# Altium schematic sheet mainb.SchDoc(mainb)
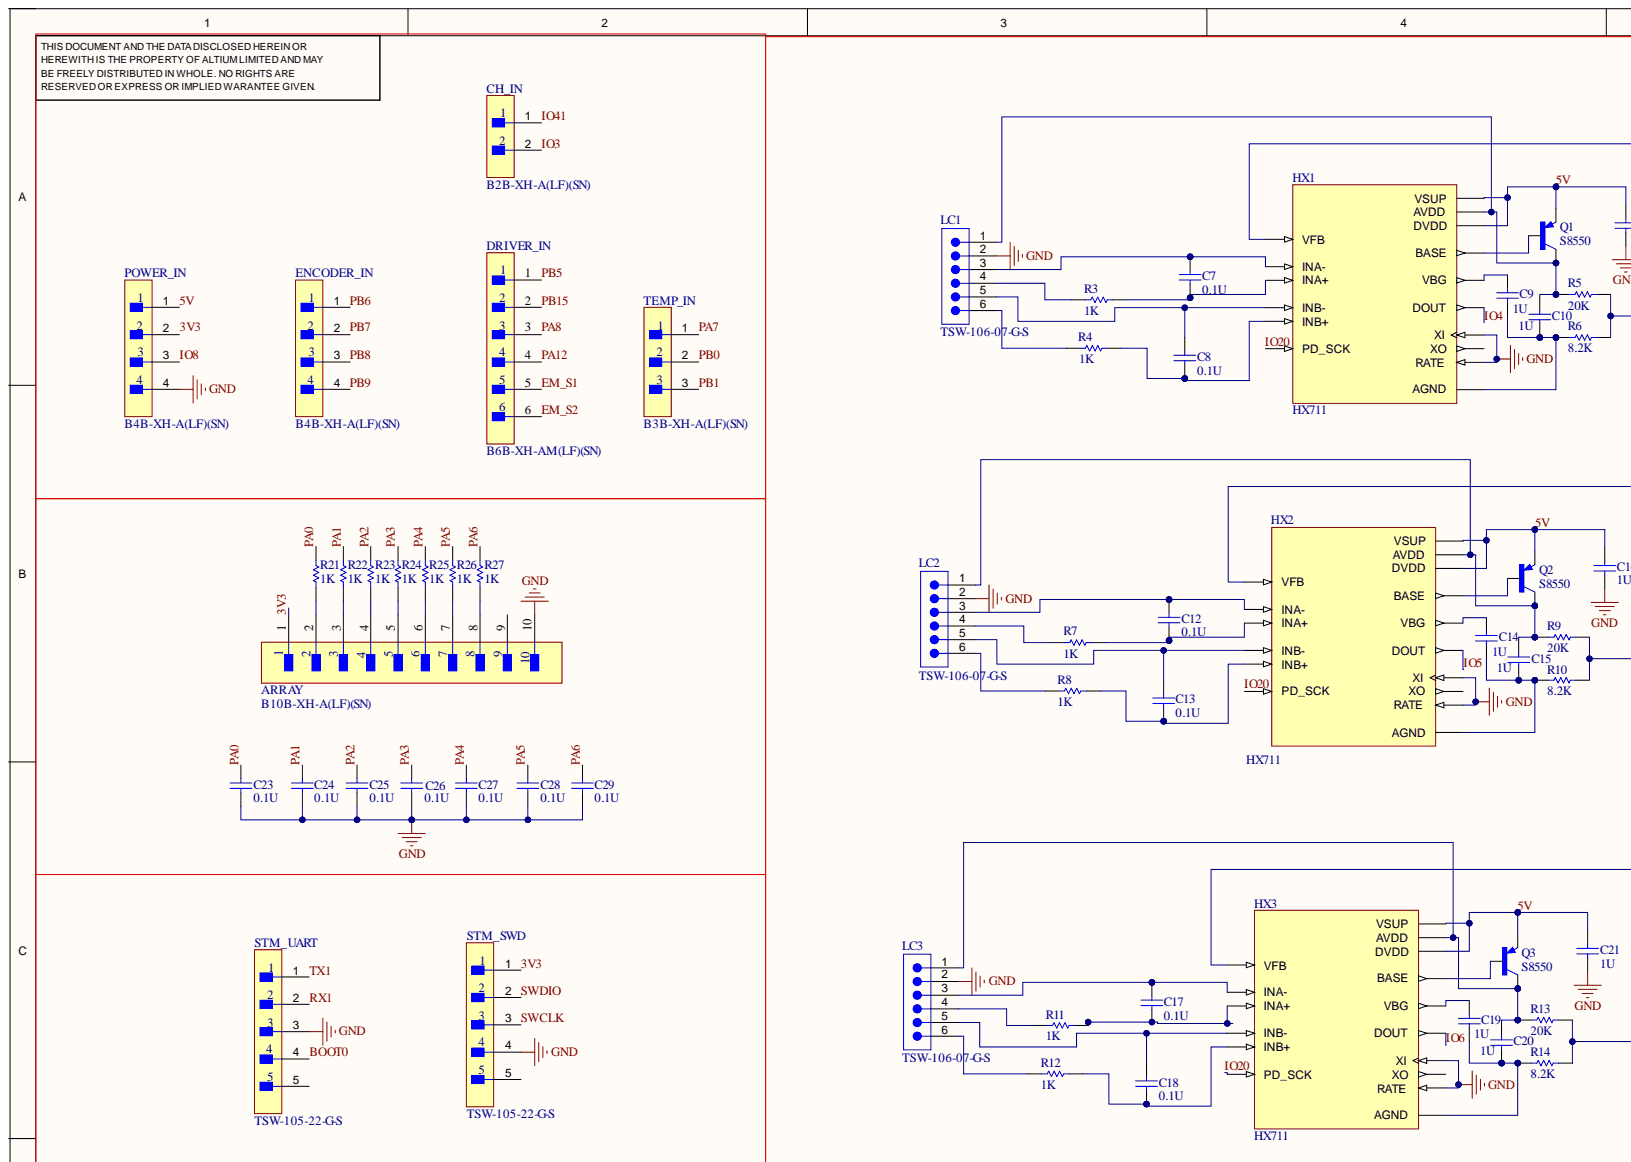
[diagram p0: mainb.SchDoc, top left]
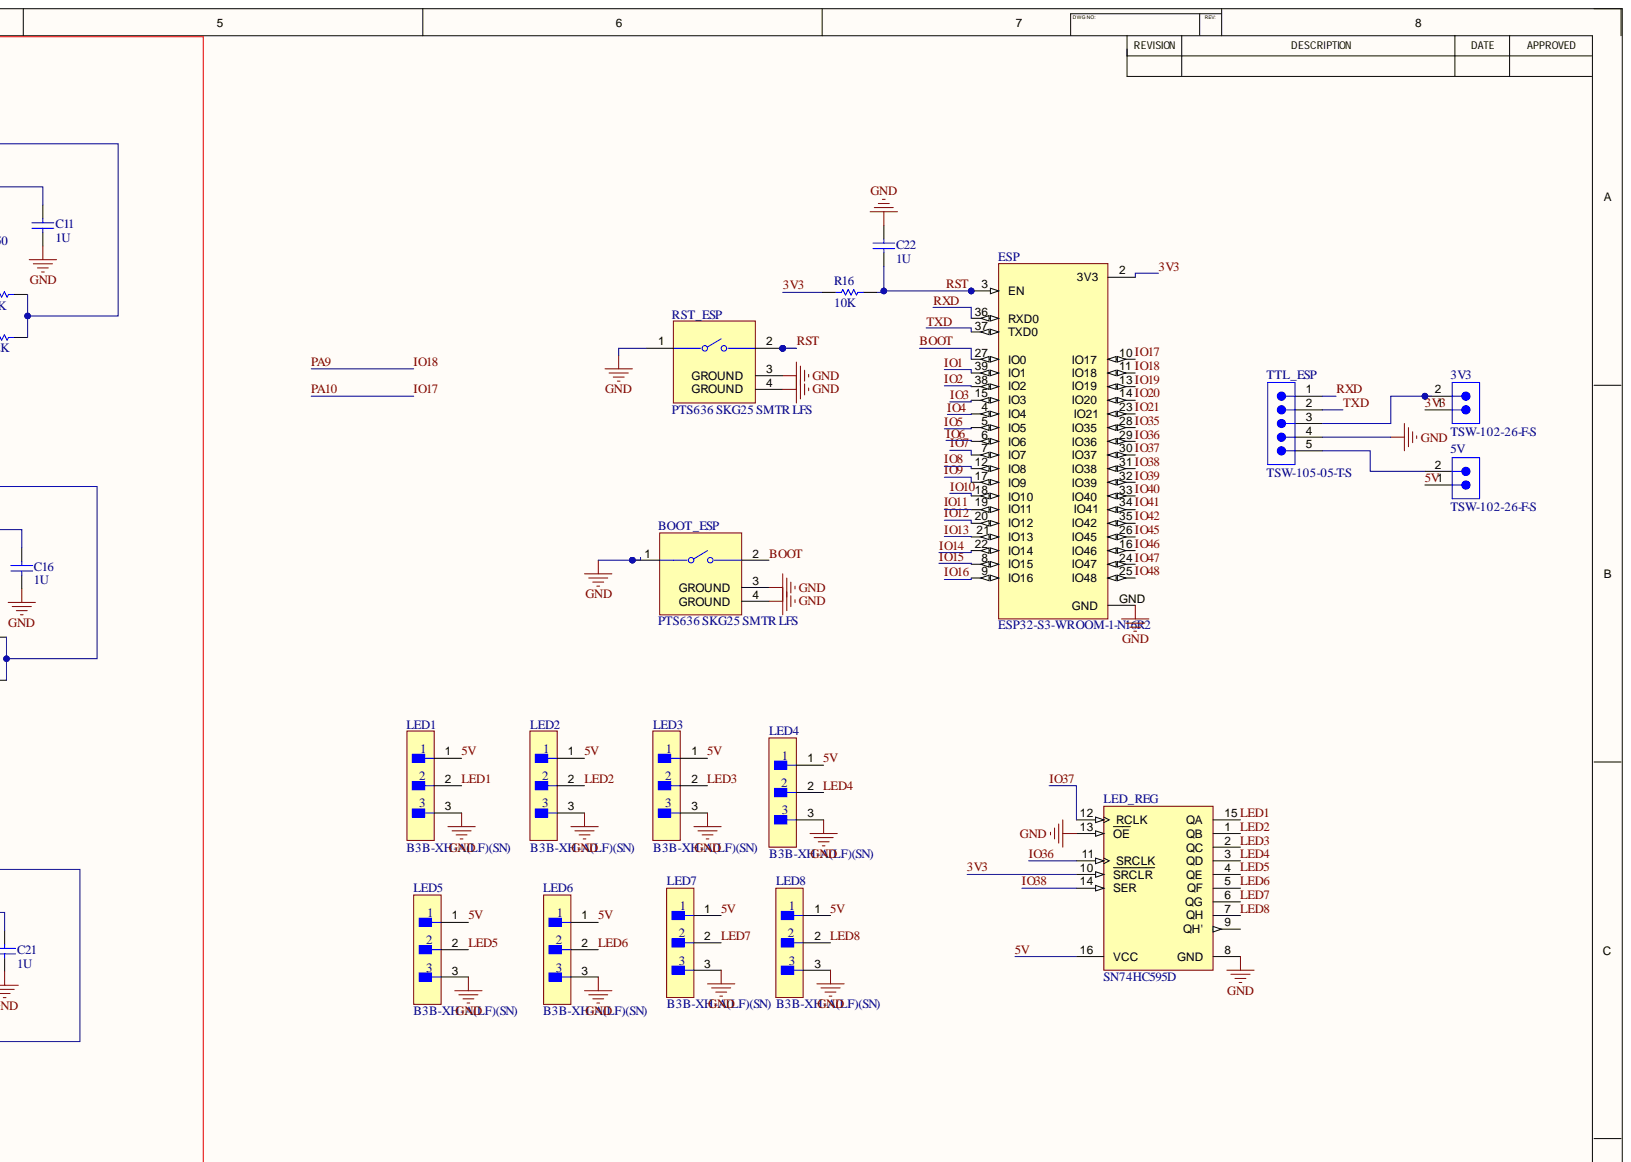
[diagram p1: mainb.SchDoc, top right]
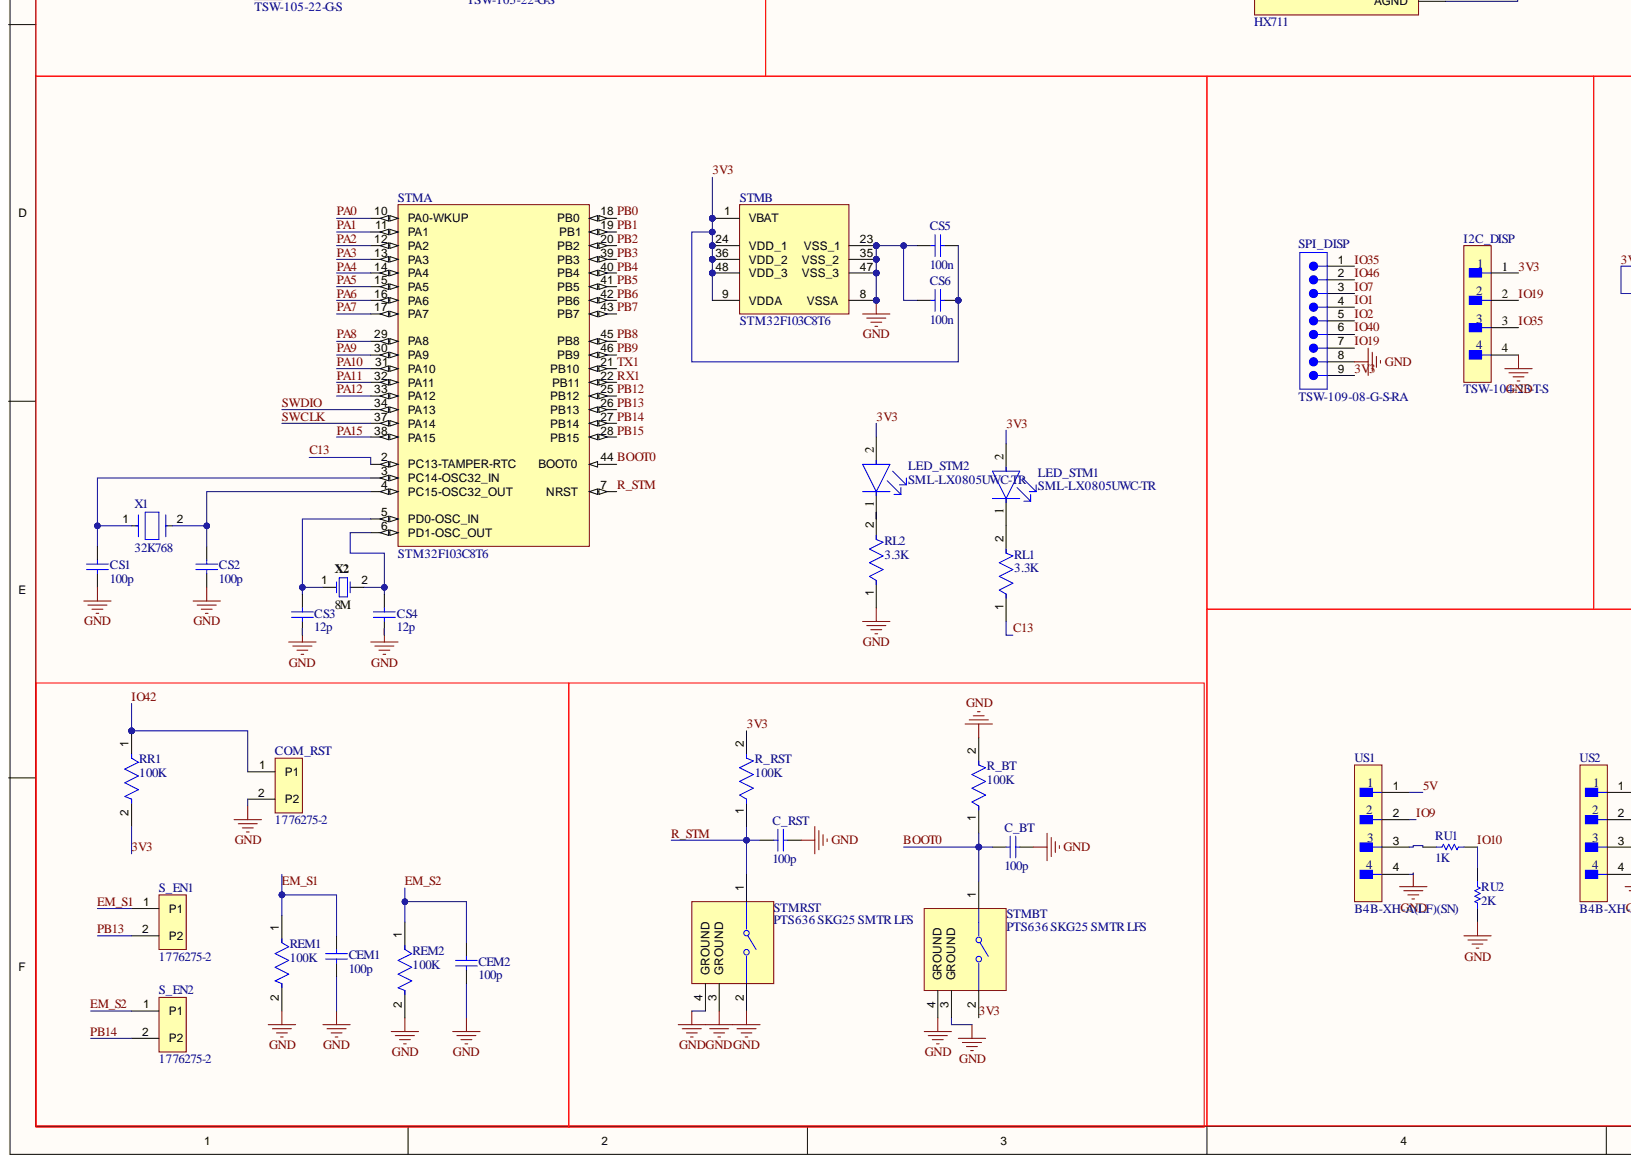
[diagram p2: mainb.SchDoc, bottom left]
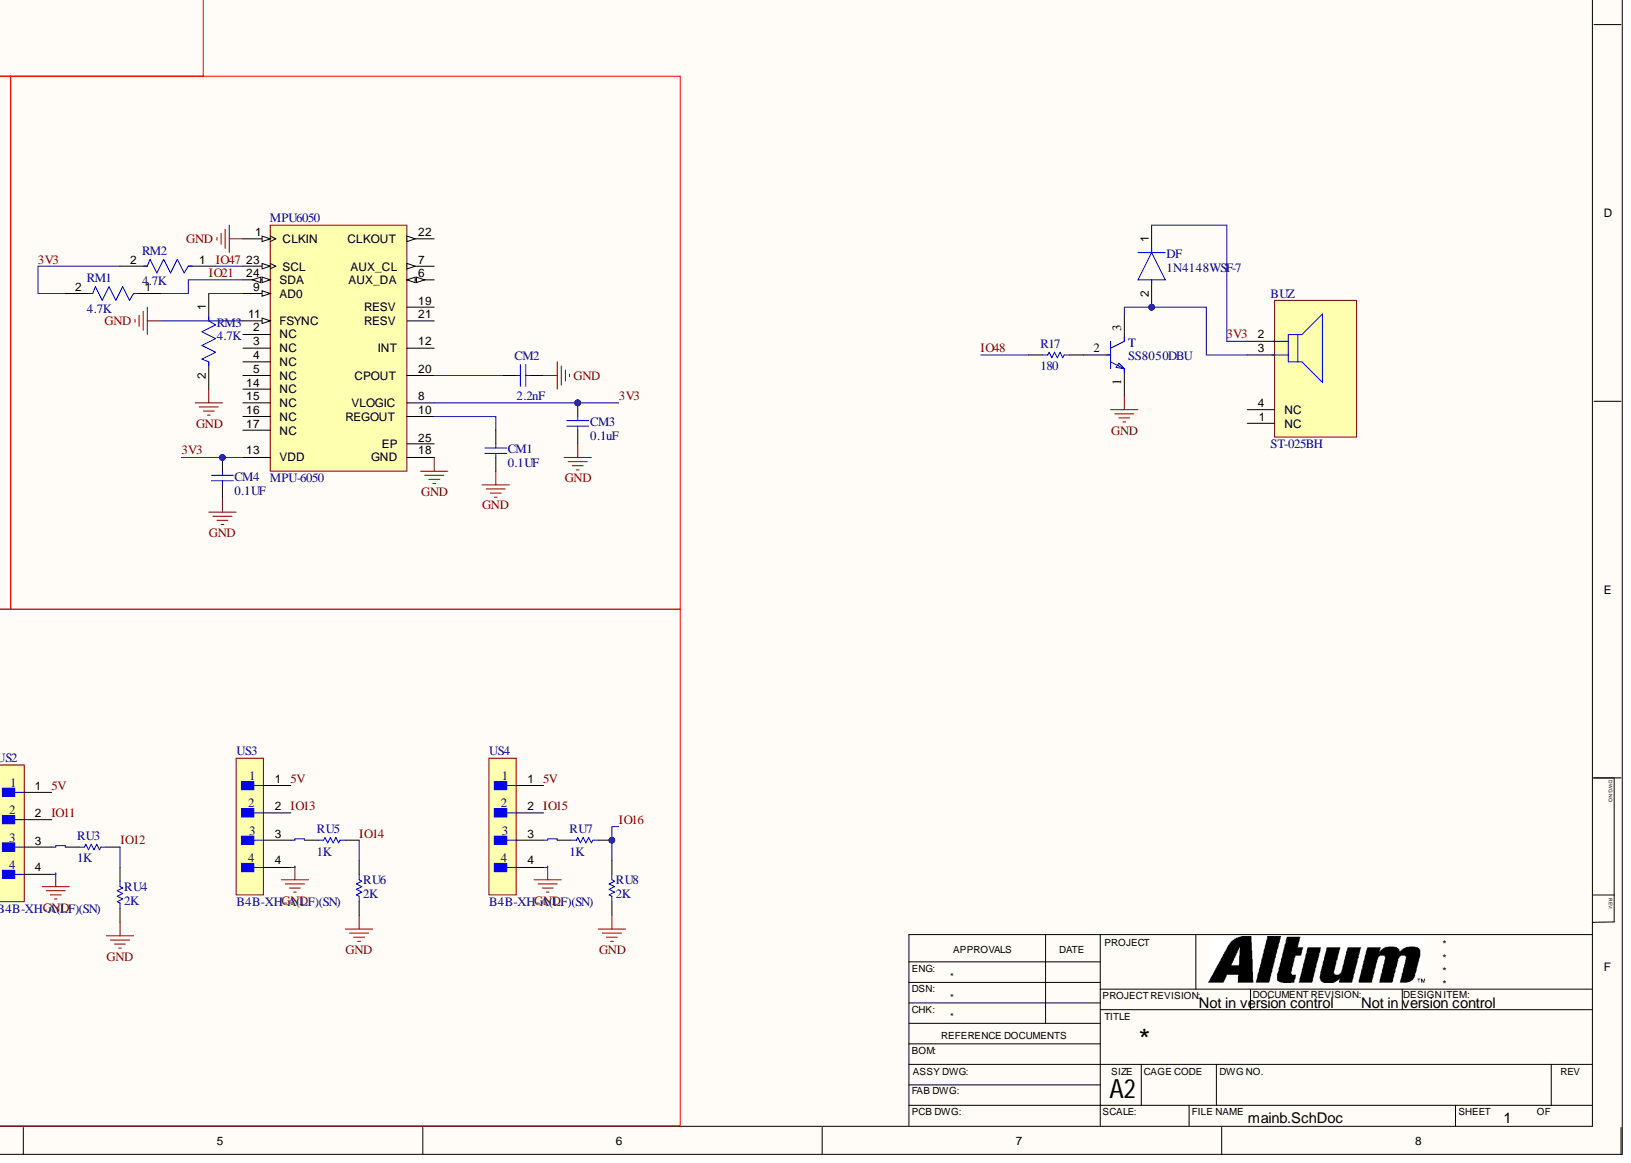
[diagram p3: mainb.SchDoc, bottom right]

## Parts
3V3  TSW-102-26-F-S  [SMTC-TSW-102-26-XX-S]
5V  TSW-102-26-F-S  [SMTC-TSW-102-26-XX-S]
ARRAY  B10B-XH-A(LF)(SN)  [FP-B10B-XH-A_LF_SN-MFG]
BOOT_ESP  PTS636 SKG25 SMTR LFS  [FP-PTS636_SKG25_SMTR_LFS-MFG]
BUZ  ST-025BH  [FP-ST-025BH-MFG]
C7  0.1U  [CAPC1608X92X38ML15T08]
C8  0.1U  [CAPC1608X92X38ML15T08]
C9  1U  [CAPC1608X92X38ML15T08]
C10  1U  [CAPC1608X92X38ML15T08]
C11  1U  [CAPC1608X92X38ML15T08]
C12  0.1U  [CAPC1608X92X38ML15T08]
C13  0.1U  [CAPC1608X92X38ML15T08]
C14  1U  [CAPC1608X92X38ML15T08]
C15  1U  [CAPC1608X92X38ML15T08]
C16  1U  [CAPC1608X92X38ML15T08]
C17  0.1U  [CAPC1608X92X38ML15T08]
C18  0.1U  [CAPC1608X92X38ML15T08]
C19  1U  [CAPC1608X92X38ML15T08]
C20  1U  [CAPC1608X92X38ML15T08]
C21  1U  [CAPC1608X92X38ML15T08]
C22  1U  [CAPC1608X92X38ML15T08]
C23  0.1U  [CAPC1608X92X38ML15T08]
C24  0.1U  [CAPC1608X92X38ML15T08]
C25  0.1U  [CAPC1608X92X38ML15T08]
C26  0.1U  [CAPC1608X92X38ML15T08]
C27  0.1U  [CAPC1608X92X38ML15T08]
C28  0.1U  [CAPC1608X92X38ML15T08]
C29  0.1U  [CAPC1608X92X38ML15T08]
C_BT  100p  [CAPC2013X145X48NL20T23]
C_RST  100p  [CAPC2013X145X48NL20T23]
CEM1  100p  [CAPC2013X145X48NL20T23]
CEM2  100p  [CAPC2013X145X48NL20T23]
CH_IN  B2B-XH-A(LF)(SN)  [FP-B2B-XH-A_LF_SN-MFG]
CM1  0.1UF  [CAPC2013X145X48NL20T23]
CM2  2.2nF  [CAPC2013X145X48NL20T23]
CM3  0.1uF  [CAPC2013X145X48NL20T23]
CM4  0.1UF  [CAPC2013X145X48NL20T23]
COM_RST  1776275-2  [TE_1776275-2]
CS1  100p  [CAPC2013X145X48NL20T23]
CS2  100p  [CAPC2013X145X48NL20T23]
CS3  12p  [CAPC2013X145X48NL20T23]
CS4  12p  [CAPC2013X145X48NL20T23]
CS5  100n  [CAPC2013X145X48NL20T23]
CS6  100n  [CAPC2013X145X48NL20T23]
DF  1N4148WSF-7  [FP-SOD323F-MFG]
DRIVER_IN  B6B-XH-AM(LF)(SN)  [FP-B6B-XH-AM_LF_SN-MFG]
ENCODER_IN  B4B-XH-A(LF)(SN)  [FP-B4B-XH-A_LF_SN-MFG]
ESP  ESP32-S3-WROOM-1-N16R2  [XCVR_ESP32-S3-WROOM-1-N16R2]
HX1  HX711  [SOP127P600X180-16N]
HX2  HX711  [SOP127P600X180-16N]
HX3  HX711  [SOP127P600X180-16N]
I2C_DISP  TSW-104-23-T-S  [FP-TSW-104-23-T-S-MFG]
LC1  TSW-106-07-G-S  [SMTC-TSW-106-07-X-S]
LC2  TSW-106-07-G-S  [SMTC-TSW-106-07-X-S]
LC3  TSW-106-07-G-S  [SMTC-TSW-106-07-X-S]
LED1  B3B-XH-A(LF)(SN)  [FP-B3B-XH-A_LF_SN-MFG]
LED2  B3B-XH-A(LF)(SN)  [FP-B3B-XH-A_LF_SN-MFG]
LED3  B3B-XH-A(LF)(SN)  [FP-B3B-XH-A_LF_SN-MFG]
LED4  B3B-XH-A(LF)(SN)  [FP-B3B-XH-A_LF_SN-MFG]
LED5  B3B-XH-A(LF)(SN)  [FP-B3B-XH-A_LF_SN-MFG]
LED6  B3B-XH-A(LF)(SN)  [FP-B3B-XH-A_LF_SN-MFG]
LED7  B3B-XH-A(LF)(SN)  [FP-B3B-XH-A_LF_SN-MFG]
LED8  B3B-XH-A(LF)(SN)  [FP-B3B-XH-A_LF_SN-MFG]
LED_REG  SN74HC595D  [D0016A_L]
LED_STM1  SML-LX0805UWC-TR  [FP-SML-LX0805UWC-TR-MFG]
LED_STM2  SML-LX0805UWC-TR  [FP-SML-LX0805UWC-TR-MFG]
MPU6050  MPU-6050  [IS-QFN-24_V]
POWER_IN  B4B-XH-A(LF)(SN)  [FP-B4B-XH-A_LF_SN-MFG]
Q1  S8550  [TRANS_S8550]
Q2  S8550  [TRANS_S8550]
Q3  S8550  [TRANS_S8550]
R3  1K  [RESC0603(1608)_N]
R4  1K  [RESC0603(1608)_N]
R5  20K  [RESC0603(1608)_N]
R6  8.2K  [RESC0603(1608)_N]
R7  1K  [RESC0603(1608)_N]
R8  1K  [RESC0603(1608)_N]
R9  20K  [RESC0603(1608)_N]
R10  8.2K  [RESC0603(1608)_N]
R11  1K  [RESC0603(1608)_N]
R12  1K  [RESC0603(1608)_N]
R13  20K  [RESC0603(1608)_N]
R14  8.2K  [RESC0603(1608)_N]
R16  10K  [RESC0603(1608)_N]
R17  180  [RESC0603(1608)_N]
R21  1K  [RESC0603(1608)_N]
R22  1K  [RESC0603(1608)_N]
R23  1K  [RESC0603(1608)_N]
R24  1K  [RESC0603(1608)_N]
R25  1K  [RESC0603(1608)_N]
R26  1K  [RESC0603(1608)_N]
R27  1K  [RESC0603(1608)_N]
R_BT  100K  [FP-RT0805-MFG]
R_RST  100K  [FP-RT0805-MFG]
REM1  100K  [FP-RT0805-MFG]
REM2  100K  [FP-RT0805-MFG]
RL1  3.3K  [FP-RT0805-MFG]
RL2  3.3K  [FP-RT0805-MFG]
RM1  4.7K  [FP-RT0805-MFG]
RM2  4.7K  [FP-RT0805-MFG]
RM3  4.7K  [FP-RT0805-MFG]
RR1  100K  [FP-RT0805-MFG]
RST_ESP  PTS636 SKG25 SMTR LFS  [FP-PTS636_SKG25_SMTR_LFS-MFG]
RU1  1K  [RESC0603(1608)_N]
RU2  2K  [RESC0603(1608)_N]
RU3  1K  [RESC0603(1608)_N]
RU4  2K  [RESC0603(1608)_N]
RU5  1K  [RESC0603(1608)_N]
RU6  2K  [RESC0603(1608)_N]
RU7  1K  [RESC0603(1608)_N]
RU8  2K  [RESC0603(1608)_N]
S_EN1  1776275-2  [TE_1776275-2]
S_EN2  1776275-2  [TE_1776275-2]
SPI_DISP  TSW-109-08-G-S-RA  [SMTC-TSW-109-08-X-S-RA]
STM  STM32F103C8T6  [STM-LQFP48_L]  (parts A,B)
STM_SWD  TSW-105-22-G-S  [FP-TSW-105-22-G-S-MFG]
STM_UART  TSW-105-22-G-S  [FP-TSW-105-22-G-S-MFG]
STMBT  PTS636 SKG25 SMTR LFS  [FP-PTS636_SKG25_SMTR_LFS-MFG]
STMRST  PTS636 SKG25 SMTR LFS  [FP-PTS636_SKG25_SMTR_LFS-MFG]
T  SS8050DBU  [FP-135AN-MFG]
TEMP_IN  B3B-XH-A(LF)(SN)  [FP-B3B-XH-A_LF_SN-MFG]
TTL_ESP  TSW-105-05-T-S  [SMTC-TSW-105-05-X-S]
US1  B4B-XH-A(LF)(SN)  [FP-B4B-XH-A_LF_SN-MFG]
US2  B4B-XH-A(LF)(SN)  [FP-B4B-XH-A_LF_SN-MFG]
US3  B4B-XH-A(LF)(SN)  [FP-B4B-XH-A_LF_SN-MFG]
US4  B4B-XH-A(LF)(SN)  [FP-B4B-XH-A_LF_SN-MFG]
X1  32K768  [FP-NX2012SA-MFG]
X2  8M  [NDK-NX3225GD-8MHZ-STD-CRA-3_V]

## Nets
GND: ARRAY.10 BOOT_ESP.1 BOOT_ESP.3(GROUND) BOOT_ESP.4(GROUND) C11.1 C16.1 C21.1 C22.1 C23.1 C24.1 C25.1 C26.1 C27.1 C28.1 C29.1 C_BT.1 C_RST.1 CEM1.2 CEM2.2 CM1.2 CM2.2 CM3.2 CM4.2 COM_RST.2 CS1.1 CS2.1 CS3.1 CS4.1 CS5.2 CS6.2 ESP.GND HX1.14(XI) HX1.15(RATE) HX2.14(XI) HX2.15(RATE) HX3.14(XI) HX3.15(RATE) I2C_DISP.4 LC1.2 LC2.2 LC3.2 LED1.3 LED2.3 LED3.3 LED4.3 LED5.3 LED6.3 LED7.3 LED8.3 LED_REG.8(GND) LED_REG.13(OE) MPU6050.1(CLKIN) MPU6050.11(FSYNC) MPU6050.18(GND) POWER_IN.4 R_BT.2 REM1.2 REM2.2 RL2.1 RM3.2 RST_ESP.1 RST_ESP.3(GROUND) RST_ESP.4(GROUND) RU2.2 RU4.2 RU6.2 RU8.2 SPI_DISP.8 STM.8(VSSA) STM.23(VSS_1) STM.35(VSS_2) STM.47(VSS_3) STM_SWD.4 STM_UART.3 STMBT.3(GROUND) STMBT.4(GROUND) STMRST.2 STMRST.3(GROUND) STMRST.4(GROUND) T.1 TTL_ESP.4 US1.4 US2.4 US3.4 US4.4
3V3: 3V3.1 ARRAY.1 BUZ.2 CM3.1 CM4.1 CS5.1 CS6.1 DF.1 ESP.2(3V3) I2C_DISP.1 LED_REG.10(SRCLR) LED_STM1.2 LED_STM2.2 MPU6050.8(VLOGIC) MPU6050.13(VDD) POWER_IN.2 R16.2 R_RST.2 RM1.2 RM2.2 RR1.2 SPI_DISP.9 STM.1(VBAT) STM.9(VDDA) STM.24(VDD_1) STM.36(VDD_2) STM.48(VDD_3) STM_SWD.1 STMBT.2
5V: 5V.1 C11.2 C16.2 C21.2 HX1.1(VSUP) HX1.16(DVDD) HX2.1(VSUP) HX2.16(DVDD) HX3.1(VSUP) HX3.16(DVDD) LED1.1 LED2.1 LED3.1 LED4.1 LED5.1 LED6.1 LED7.1 LED8.1 LED_REG.16(VCC) POWER_IN.1 Q1.2 Q2.2 Q3.2 US1.1 US2.1 US3.1 US4.1
BOOT: BOOT_ESP.2 ESP.27(IO0)
BOOT0: C_BT.2 R_BT.1 STM.44(BOOT0) STM_UART.4 STMBT.1
C13: RL1.1 STM.2(PC13-TAMPER-RTC)
EM_S1: CEM1.1 DRIVER_IN.5 REM1.1 S_EN1.1
EM_S2: CEM2.1 DRIVER_IN.6 REM2.1 S_EN2.1
IO1: ESP.39(IO1) SPI_DISP.4
IO2: ESP.38(IO2) SPI_DISP.5
IO3: CH_IN.2 ESP.15(IO3)
IO4: ESP.4(IO4) HX1.12(DOUT)
IO5: ESP.5(IO5) HX2.12(DOUT)
IO6: ESP.6(IO6) HX3.12(DOUT)
IO7: ESP.7(IO7) SPI_DISP.3
IO8: ESP.12(IO8) POWER_IN.3
IO9: ESP.17(IO9) US1.2
IO10: ESP.18(IO10) RU1.1 RU2.1
IO11: ESP.19(IO11) US2.2
IO12: ESP.20(IO12) RU3.1 RU4.1
IO13: ESP.21(IO13) US3.2
IO14: ESP.22(IO14) RU5.1 RU6.1
IO15: ESP.8(IO15) US4.2
IO16: ESP.9(IO16) RU7.1 RU8.1
IO17: ESP.10(IO17) STM.31(PA10)
IO18: ESP.11(IO18) STM.30(PA9)
IO19: ESP.13(IO19) I2C_DISP.2 SPI_DISP.7
IO20: ESP.14(IO20) HX1.11(PD_SCK) HX2.11(PD_SCK) HX3.11(PD_SCK)
IO21: ESP.23(IO21) MPU6050.24(SDA) RM1.1
IO35: ESP.28(IO35) I2C_DISP.3 SPI_DISP.1
IO36: ESP.29(IO36) LED_REG.11(SRCLK)
IO37: ESP.30(IO37) LED_REG.12(RCLK)
IO38: ESP.31(IO38) LED_REG.14(SER)
IO39: ESP.32(IO39)
IO40: ESP.33(IO40) SPI_DISP.6
IO41: CH_IN.1 ESP.34(IO41)
IO42: COM_RST.1 ESP.35(IO42) RR1.1
IO45: ESP.26(IO45)
IO46: ESP.16(IO46) SPI_DISP.2
IO47: ESP.24(IO47) MPU6050.23(SCL) RM2.1
IO48: ESP.25(IO48) R17.2
LED1: LED1.2 LED_REG.15(QA)
LED2: LED2.2 LED_REG.1(QB)
LED3: LED3.2 LED_REG.2(QC)
LED4: LED4.2 LED_REG.3(QD)
LED5: LED5.2 LED_REG.4(QE)
LED6: LED6.2 LED_REG.5(QF)
LED7: LED7.2 LED_REG.6(QG)
LED8: LED8.2 LED_REG.7(QH)
PA0: C23.2 R21.1 STM.10(PA0-WKUP)
PA1: C24.2 R22.1 STM.11(PA1)
PA2: C25.2 R23.1 STM.12(PA2)
PA3: C26.2 R24.1 STM.13(PA3)
PA4: C27.2 R25.1 STM.14(PA4)
PA5: C28.2 R26.1 STM.15(PA5)
PA6: C29.2 R27.1 STM.16(PA6)
PA7: STM.17(PA7) TEMP_IN.1
PA8: DRIVER_IN.3 STM.29(PA8)
PA11: STM.32(PA11)
PA12: DRIVER_IN.4 STM.33(PA12)
PA15: STM.38(PA15)
PB0: STM.18(PB0) TEMP_IN.2
PB1: STM.19(PB1) TEMP_IN.3
PB2: STM.20(PB2)
PB3: STM.39(PB3)
PB4: STM.40(PB4)
PB5: DRIVER_IN.1 STM.41(PB5)
PB6: ENCODER_IN.1 STM.42(PB6)
PB7: ENCODER_IN.2 STM.43(PB7)
PB8: ENCODER_IN.3 STM.45(PB8)
PB9: ENCODER_IN.4 STM.46(PB9)
PB12: STM.25(PB12)
PB13: S_EN1.2 STM.26(PB13)
PB14: S_EN2.2 STM.27(PB14)
PB15: DRIVER_IN.2 STM.28(PB15)
R_STM: C_RST.2 R_RST.1 STM.7(NRST) STMRST.1
RST: C22.2 ESP.3(EN) R16.1 RST_ESP.2
RX1: STM.22(PB11) STM_UART.2
RXD: ESP.36(RXD0) TTL_ESP.1
SWCLK: STM.37(PA14) STM_SWD.3
SWDIO: STM.34(PA13) STM_SWD.2
TX1: STM.21(PB10) STM_UART.1
TXD: ESP.37(TXD0) TTL_ESP.2
Net3V3_2: 3V3.2 TTL_ESP.3
Net5V_2: 5V.2 TTL_ESP.5
NetARRAY_2: ARRAY.2 R21.2
NetARRAY_3: ARRAY.3 R22.2
NetARRAY_4: ARRAY.4 R23.2
NetARRAY_5: ARRAY.5 R24.2
NetARRAY_6: ARRAY.6 R25.2
NetARRAY_7: ARRAY.7 R26.2
NetARRAY_8: ARRAY.8 R27.2
NetBUZ_3: BUZ.3 DF.2 T.3
NetC7_1: C7.1 HX1.8(INA+) R3.1
NetC7_2: C7.2 HX1.7(INA-) LC1.3
NetC8_1: C8.1 HX1.10(INB+) R4.1
NetC8_2: C8.2 HX1.9(INB-) LC1.5
NetC9_1: C9.1 C10.1 HX1.5(AGND) R6.2
NetC9_2: C9.2 HX1.6(VBG)
NetC10_2: C10.2 HX1.3(AVDD) LC1.1 Q1.3 R5.2
NetC12_1: C12.1 HX2.8(INA+) R7.1
NetC12_2: C12.2 HX2.7(INA-) LC2.3
NetC13_1: C13.1 HX2.10(INB+) R8.1
NetC13_2: C13.2 HX2.9(INB-) LC2.5
NetC14_1: C14.1 C15.1 HX2.5(AGND) R10.2
NetC14_2: C14.2 HX2.6(VBG)
NetC15_2: C15.2 HX2.3(AVDD) LC2.1 Q2.3 R9.2
NetC17_1: C17.1 HX3.8(INA+) R11.1
NetC17_2: C17.2 HX3.7(INA-) LC3.3
NetC18_1: C18.1 HX3.10(INB+) R12.1
NetC18_2: C18.2 HX3.9(INB-) LC3.5
NetC19_1: C19.1 C20.1 HX3.5(AGND) R14.2
NetC19_2: C19.2 HX3.6(VBG)
NetC20_2: C20.2 HX3.3(AVDD) LC3.1 Q3.3 R13.2
NetCM1_1: CM1.1 MPU6050.10(REGOUT)
NetCM2_1: CM2.1 MPU6050.20(CPOUT)
NetCS1_2: CS1.2 STM.3(PC14-OSC32_IN) X1.1
NetCS2_2: CS2.2 STM.4(PC15-OSC32_OUT) X1.2
NetCS3_2: CS3.2 STM.5(PD0-OSC_IN) X2.1
NetCS4_2: CS4.2 STM.6(PD1-OSC_OUT) X2.2
NetHX1_2: HX1.2(BASE) Q1.1
NetHX1_4: HX1.4(VFB) R5.1 R6.1
NetHX2_2: HX2.2(BASE) Q2.1
NetHX2_4: HX2.4(VFB) R9.1 R10.1
NetHX3_2: HX3.2(BASE) Q3.1
NetHX3_4: HX3.4(VFB) R13.1 R14.1
NetLC1_4: LC1.4 R3.2
NetLC1_6: LC1.6 R4.2
NetLC2_4: LC2.4 R7.2
NetLC2_6: LC2.6 R8.2
NetLC3_4: LC3.4 R11.2
NetLC3_6: LC3.6 R12.2
NetLED_STM1_1: LED_STM1.1 RL1.2
NetLED_STM2_1: LED_STM2.1 RL2.2
NetMPU6050_9: MPU6050.9(AD0) RM3.1
NetR17_1: R17.1 T.2
NetRU1_2: RU1.2 US1.3
NetRU3_2: RU3.2 US2.3
NetRU5_2: RU5.2 US3.3
NetRU7_2: RU7.2 US4.3

Not connected: ARRAY 9; BUZ 1(NC) 4(NC); HX1 13(XO); HX2 13(XO); HX3 13(XO); LED_REG 9(QH'); MPU6050 2(NC) 3(NC) 4(NC) 5(NC) 6(AUX_DA) 7(AUX_CL) 12(INT) 14(NC) 15(NC) 16(NC) 17(NC) 19(RESV) 21(RESV) 22(CLKOUT) 25(EP); STM_SWD 5; STM_UART 5

## Library data of the parts
3V3 5V = CMP-1024-00329-1: 0.025" SQ Post Header, Through-hole, Vertical, -55 to 125 degC, 2.54 mm Pitch, 2-Pin, Male, RoHS; Manufacturer: Samtec; Pitch: 2.54 mm; Component Kind: Standard; ComponentLink1Description: Manufacturer URL; ComponentLink1URL: http://www.samtec.com; ComponentLink2Description: Datasheet; ComponentLink2URL: http://www.samtec.com/documents/webfiles/pdf/TSW_TH.PDF; ComponentLink3Description: Package Specification; ComponentLink3URL: http://www.samtec.com/documents/webfiles/cpdf/TSW-XXX-XX-XXX-X-XX-XXX-MKT.pdf; DatasheetVersion: F-214; Library Name: Altium Content Vault; Mounting Technology: Through-hole; Orientation: Vertical; PackageDescription: .025[0.64] SQ. Post Terminal Strip Assembly; PackageReference: TSW-102-26-XX-S; PackageVersion: DM, 8/1988; PartNumber: TSW-102-26-F-S; RoHS: True; Temperature Range: -55 to 125 degC
ARRAY = CMP-17439-000145-1: CONN HEADER VERT 10POS 2.5MM; Contact Resistance: 20mR; Current Rating: 3A; Gender: Male; Insulation Resistance: 1GR; Mated Stacking Heights: 9.8mm; Max Voltage Rating (AC): 250V; Number of Contacts: 10; Wire/Cable Gauge: 22AWG; Color: Natural; Component Kind: Standard; Connector Type: Header; Contact Finish - Mating: TIN; Contact Material: Brass; Contact Plating: Tin; Contact Shape: Square; Contact Type: Male Pin; Depth: 5.75mm; Fastening Type: Detent; Flammability Rating: UL94 V-0; Housing Color: White; Housing Material: Polyamide; Insulation Color: Natural; Insulation Material: Nylon; Lead Free: Lead Free; Lead Pitch: 2.5mm; Length: 27.4mm; Library Name: Altium Content Vault; Max Current Rating: 3A; Max Operating Temperature: 85°C; Max Voltage Rating (DC): 250V; Min Operating Temperature: -25°C; Mount: Through Hole; Number of Positions: 10; Number of Rows: 1; Orientation: Straight; Packaging: Bulk; Pitch: 2.5mm; REACH SVHC: No SVHC; Series: XH; Termination: Solder; Voltage Rating: 250V; Voltage Rating (AC): 250V
BOOT_ESP RST_ESP STMBT STMRST = CMP-10040-000025-1: TACT 6.0 X 3.5, 2.5 MM H, 250GF,; Component Kind: Standard; Library Name: Altium Content Vault
BUZ = CMP-247804-000002-1: AUDIO MAGNETIC XDCR 2-4V SMD; Manufacturer: Soberton Inc.; Current: 100mA; Frequency: 2700Hz; Max Supply Voltage: 4.5V; Min Supply Voltage: 2.5V; Operating Supply Voltage: 3.6V; Automotive: No; Category: Speak; Component Kind: Standard; ComponentLink2Description: Datasheet; ComponentLink2URL: https://www.soberton.com/wp-content/uploads/2019/02/ST-025BH-19-Feb-2019.pdf; Device Class L1: Electromechanical; Device Class L2: Audio Products; Device Class L3: Buzzers; Digikey Description: AUDIO MAGNETIC XDCR 2-4V SMD; Height: 3mm; Lead Free: Yes; Library Name: Altium Content Vault; Manufacturer Part Number: ST-025BH; Octopart: https://octopart.com/st-025bh-soberton-22171825; Package: ST-025BH; RoHS: TRUE; Temperature: -30°C to +85°C; Temperature Range High: +85°C; Temperature Range Low: -30°C
C7-C29 = CMP-2006-01200-1: Manufacturer: Vitramon; Part Number: VJ0603Y102KXJAC; Component Kind: Standard; Library Name: Altium Content Vault
C_BT C_RST CEM1 CEM2 CM1-CM4 CS1-CS6 = CMP-2007-01815-1: Manufacturer: Vitramon; Part Number: VJ0805Y222KXBAC; Component Kind: Standard; Library Name: Altium Content Vault
CH_IN = CMP-2000-05888-3: CONN HEADER VERT 2POS 2.5MM; Connector Type: Male Pin; Contact Resistance: 20mR; Current Rating: 3A; Insulation Resistance: 1GR; Max Voltage Rating (AC): 250V; Number of Contacts: 2; Wire/Cable Gauge: 22AWG; Color: Natural; Component Kind: Standard; Contact Material: Brass; Contact Plating: Tin; Contact Type: Male Pin; Depth: 5.75mm; Fastening Type: Detent; Housing Color: White; Housing Material: Polyamide; Lead Free: Lead Free; Lead Pitch: 2.5mm; Length: 7.4mm; Library Name: Altium Content Vault; Max Current Rating: 3A; Max Operating Temperature: 85°C; Max Voltage Rating (DC): 250V; Min Operating Temperature: -25°C; Mount: Through Hole; Number of Positions: 2; Number of Rows: 1; Orientation: Straight; Packaging: Bulk; Pitch: 2.5mm; REACH SVHC: No SVHC; Series: XH; Termination: Solder; Voltage Rating: 250V; Voltage Rating (AC): 250V
COM_RST S_EN1 S_EN2 = 1776275-2: 2 Position Wire to Board Terminal Block Horizontal with Board 0.138" (3.50mm) Through Hole; MF: TE Connectivity; Availability: Unavailable; Check_prices: https://www.snapeda.com/parts/1776275-2/TE+Connectivity+AMP+Connectors/view-part/?ref=eda; Component Kind: Standard; MP: 1776275-2; Package: None; Price: None; SnapEDA_Link: https://www.snapeda.com/parts/1776275-2/TE+Connectivity+AMP+Connectors/view-part/?ref=snap; Library Name: stb-2.IntLib
DF = CMP-12791-000029-1: DIODE GEN PURP 100V 250MA SOD323; Manufacturer: Diodes Inc.; Capacitance: 1.5pF; Max Junction Temp: +150°C; Max Surge Forward Current: 0.5A; Peak Reverse Current: 0.5uA; Value: 1.5pF; Automotive: No; Category: Diode; Component Kind: Standard; ComponentLink2Description: Datasheet; ComponentLink2URL: https://www.diodes.com/assets/Datasheets/1N4148WSF.pdf; Device Class L1: Discrete Semiconductors; Device Class L2: Diodes; Device Class L3: Rectifier Diodes; Digikey Description: DIODE GEN PURP 100V 250MA SOD323; Footprint Url: https://www.diodes.com/assets/Package-Files/SOD323F.pdf; Forward Current: 250mA; Height: 0.75mm; Lead Free: Yes; Library Name: Altium Content Vault; Manufacturer Part Number: 1N4148WSF-7; Max Repetitive Reverse Voltage: 100V; Mouser Description: Diodes - General Purpose, Power, Switching 200mW 2pF 75Vrrm 150mA 2A 1VF; Octopart: https://octopart.com/1n4148wsf-7-diodes+inc.-33798544; Package: SOD323F; Reverse Voltage: 100V; RoHS: TRUE; Temperature: -55°C to +150°C; Temperature Range High: +150°C; Temperature Range Low: -55°C
DRIVER_IN = CMP-17439-000167-1: CONN HEADER VERT 6POS 2.5MM; Current Rating: 3A; Mated Stacking Heights: 9.8mm; Number of Poles: 6; Shape: Straight; Voltage Rating: 250V; Component Kind: Standard; Connector Type: Header; Contact Finish - Mating: TIN; Contact Material: Brass; Contact Plating: Copper; Contact Shape: Square; Contact Type: Male Pin; Fastening Type: Detent; Flammability Rating: UL94 V-0; Height: 7mm; Insulation Color: Natural; Insulation Material: Nylon; Length: 17.4mm; Library Name: Altium Content Vault; Max Operating Temperature: 85°C; Min Operating Temperature: -25°C; Mount: Through Hole; Number of Pins: 6; Number of Positions: 6; Number of Rows: 1; Packaging: Bulk; Pitch: 2.5mm; Series: XH; Termination: Press-Fit; Width: 5.75mm
ENCODER_IN POWER_IN US1-US4 = CMP-17439-000250-1: CONN HEADER VERT 4POS 2.5MM; Contact Resistance: 20mR; Current Rating: 3A; Gender: Male; Insulation Resistance: 1GR; Mated Stacking Heights: 9.8mm; Max Voltage Rating (AC): 250V; Number of Contacts: 4; Wire/Cable Gauge: 22AWG; Color: Natural; Component Kind: Standard; Connector Type: Header; Contact Finish - Mating: TIN; Contact Material: Brass; Contact Plating: Tin; Contact Shape: Square; Contact Type: Male Pin; Depth: 5.75mm; Fastening Type: Detent; Flammability Rating: UL94 V-0; Housing Color: White; Housing Material: Polyamide; Insulation Color: Natural; Insulation Material: Nylon; Lead Free: Lead Free; Lead Pitch: 2.5mm; Length: 12.4mm; Library Name: Altium Content Vault; Material: Polyamide; Max Current Rating: 3A; Max Operating Temperature: 85°C; Max Voltage Rating (DC): 250V; Min Operating Temperature: -25°C; Mount: Through Hole; Number of Positions: 4; Number of Rows: 1; Orientation: Straight; Package Quantity: 500; Packaging: Bulk; Pitch: 2.5mm; REACH SVHC: No SVHC; Series: XH; Termination: Solder; Voltage Rating: 250V; Voltage Rating (AC): 250V
ESP = ESP32-S3-WROOM-1-N16R2: Bluetooth, WiFi 802.11b/g/n, Bluetooth v5.0 Transceiver Module 2.4GHz PCB Trace Surface Mount; MF: Espressif Systems; MP: ESP32S3WROOM1N16R2; Check_prices: https://www.snapeda.com/parts/ESP32S3WROOM1N16R2/Espressif+Systems/view-part/?ref=eda; Component Kind: Standard; Library Name: ESP32-S3-WROOM-1-N16R2.IntLib; Package: NON STANDARD Espressif Systems; SnapEDA_Link: https://www.snapeda.com/parts/ESP32S3WROOM1N16R2/Espressif+Systems/view-part/?ref=snap
HX1-HX3 = HX711: TartÄ±m terazileri ve endÃ¼striyel kontrol uygulamalarÄ±nÄ±n doÄŸrudan bir kÃ¶prÃ¼ sensÃ¶rÃ¼yle arayÃ¼z oluÅŸturmasÄ± iÃ§in tasarlanmÄ±ÅŸ hassas 24 bit analog-dijital dÃ¶nÃ¼ÅŸtÃ¼rÃ¼cÃ¼ (ADC).; MF: AVIA SEMICONDUCTOR; Check_prices: https://www.snapeda.com/parts/HX711/AVIA+SEMICONDUCTOR/view-part/?ref=eda; Component Kind: Standard; Library Name: HX711.IntLib; MP: HX711; Package: None; SnapEDA_Link: https://www.snapeda.com/parts/HX711/AVIA+SEMICONDUCTOR/view-part/?ref=snap
I2C_DISP = CMP-02766-001388-1: CONN HEADER VERT 4POS 2.54MM; Connector Type: Male Pin; Gender: Male; Max Current Rating: 7.6A; Max Voltage Rating (AC): 550V; Number of Contacts: 4; Color: Black; Component Kind: Standard; Contact Material: Bronze; Contact Mating Length: 0.23inch; Contact Plating: Tin; Contact Type: Male Pin; Depth: 2.54mm; Housing Color: Black; Housing Material: Polyester; Lead Free: Lead Free; Length: 10.16mm; Library Name: Altium Content Vault; Max Operating Temperature: 105°C; Min Operating Temperature: -55°C; Mount: Through Hole; Number of Positions: 4; Number of Rows: 1; Orientation: Straight; Package Quantity: 1; Packaging: Bulk; Pitch: 2.54mm; Radiation Hardening: No; REACH SVHC: No SVHC; RoHS Compliant: Yes; Series: TSW; Termination: Solder; Width: 2.54mm
LC1-LC3 = CMP-1024-00383-1: 0.025" SQ Post Header, Through-hole, Vertical, -55 to 125 degC, 2.54 mm Pitch, 6-Pin, Male, RoHS; Manufacturer: Samtec; Pitch: 2.54 mm; Component Kind: Standard; ComponentLink1Description: Manufacturer URL; ComponentLink1URL: http://www.samtec.com; ComponentLink2Description: Datasheet; ComponentLink2URL: http://www.samtec.com/documents/webfiles/pdf/TSW_TH.PDF; ComponentLink3Description: Package Specification; ComponentLink3URL: http://www.samtec.com/documents/webfiles/cpdf/TSW-XXX-XX-XXX-X-XX-XXX-MKT.pdf; DatasheetVersion: F-214; Library Name: Altium Content Vault; Mounting Technology: Through-hole; Orientation: Vertical; PackageDescription: .025[0.64] SQ. Post Terminal Strip Assembly; PackageReference: TSW-106-07-X-S; PackageVersion: DM, 8/1988; PartNumber: TSW-106-07-G-S; RoHS: True; Temperature Range: -55 to 125 degC
LED1-LED8 = CMP-17439-000014-3: CONN HEADER VERT 3POS 2.5MM; Color: Natural; Contact Resistance: 20mR; Current Rating: 3A; Gender: Male; Insulation Resistance: 1GR; Max Voltage Rating (AC): 250V; Number of Contacts: 3; Wire/Cable Gauge: 22AWG; Component Kind: Standard; Connector Type: Header; Contact Finish - Mating: TIN; Contact Material: Brass; Contact Plating: Tin; Contact Shape: Square; Contact Type: Male Pin; Depth: 5.75mm; Fastening Type: Detent; Flammability Rating: UL94 V-0; Housing Color: White; Housing Material: Polyamide; Insulation Color: Natural; Insulation Material: Nylon; Lead Free: Lead Free; Lead Pitch: 2.5mm; Length: 9.9mm; Library Name: Altium Content Vault; Max Current Rating: 3A; Max Operating Temperature: 85°C; Max Voltage Rating (DC): 250V; Min Operating Temperature: -25°C; Mount: Through Hole; Number of Positions: 3; Number of Rows: 1; Orientation: Straight; Packaging: Bulk; Pitch: 2.5mm; REACH SVHC: No SVHC; Series: XH; Termination: Solder; Voltage Rating: 250V; Voltage Rating (AC): 250V
LED_REG = CMP-0780-00038-3: 8-Bit Shift Registers With 3-State Output Registers, D0016A, TUBE; Manufacturer: Texas Instruments; 3-State Output: Yes; Bits: 8; F @ Nom Voltage(Max)(Mhz): 28; ICC @ Nom Voltage(Max)(mA): 0.08; Input Type: CMOS; Output Drive (IOL/IOH)(Max)(mA): 7.8/-7.8; Rating: Military; Technology Family: HC; tpd @ Nom Voltage(Max)(ns): 34; VCC(Max)(V): 6; VCC(Min)(V): 2; Code_JEDEC: MS-012 variation AC; Component Kind: Standard; DatasheetVersion: SCLS041H; Generic Part Number: SN74HC595; Library Name: Altium Content Vault; Operating Temperature Range(C): -40 to 85; Output Type: CMOS; PackageReference: D0016A; PackageVersion: http://www.ti.com/lit/ml/mpds178g/mpds178g.pdf; Voltage(Nom)(V): 6
LED_STM1 LED_STM2 = CMP-00229-00139794-1: SML-LX0805UWC-TR White LED, 0805 Diffused, Rectangle Lens SMD package; NexarPartId: 139794; Approvals: CSA, UL; Forward Current: 20mA; Forward Voltage: 3.5V; Lens Color: Clear, Yellow; Luminous Intensity: 150mcd; Number of LEDs: 1; Power Rating: 120mW; Viewing Angle: 120°; Case/Package: 0805; Component Kind: Standard; Illumination Color: White; Library Name: Altium Content Vault; Max Operating Temperature: 85°C; Min Operating Temperature: -40°C; Mount: Surface Mount; RoHS: Compliant
MPU6050 = CMP-1753-00002-2: 3-Axis Gyroscope, Accelerometer and a Digital Motion Processor(TM) (DMP), 2.375 to 3.46 V, -40 to 85 degC, 24-Pin QFN, RoHS, Tape and Reel; Manufacturer: InvenSense; Dynamic Catalog: MPU-6000™Series; Featured Product: MPU-60506-Axis,IntegratedI²CSolution; Other Names: 1428-1007-2  MPU6050; Sensor Type: MotionTracking; Category: Sensors,Transducers; Component Kind: Standard; Datasheet URL: http://datasheet.octopart.com/MPU-6050-InvenSense-datasheet-14421344.pdf; Datasheet Version: Rev. 3.3, 05/2012; Family: Multifunction; Library Name: Altium Content Vault; Manufacturer URL: http://www.invensense.com/; Online Catalog: MPU-6000™Series; Output Type: Digital; Standard Package: 5,000; Unit PriceUSD: Digital
Q1-Q3 = S8550: Bipolar (BJT) Transistor PNP 25 V 500 mA 150MHz 300 mW Surface Mount SOT-23; MF: MDD; Check_prices: https://www.snapeda.com/parts/S8550/MDD/view-part/?ref=eda; Component Kind: Standard; Library Name: S8550.IntLib; MP: S8550; Package: SOT-23 MDD; SnapEDA_Link: https://www.snapeda.com/parts/S8550/MDD/view-part/?ref=snap
R3-R14 R16 R17 R21-R27 RU1-RU8 = CMP-1012-00122-1: 100K 0.1W 5% 0603 (1608 Metric)  SMD; Case-EIA: 0603; Case-Metric: 1608; Value: 100000.00 Ohm; Component Kind: Standard; Library Name: Altium Content Vault; Power: 0.1 W; Technology: SMT; Tolerance: 5 %
R_BT R_RST REM1 REM2 RL1 RL2 RM1-RM3 RR1 = CMP-03412-000032-1: RES SMD 5.1 OHM 0.1% 1/8W 0805; Manufacturer: Yageo; Manufacturer Part Number: RT0805BRD075R1L; Power Rating: 0.125W; Resistance: 5.1Ω; Temperature Coefficient: 25 ppm/°C; Tolerance: 0.0025; Value: 5.1Ω; Voltage Rating: 150V; Automotive: No; Category: Res; Component Kind: Standard; ComponentLink2Description: Datasheet; ComponentLink2URL: http://www.yageo.com/documents/recent/PYu-RT_1-to-0.01_RoHS_L_9.pdf; Composition: Thin Film; Device Class L1: Passive Components; Device Class L2: Resistors; Device Class L3: Chip SMD Resistors; Digikey Description: RES SMD 5.1 OHM 0.1% 1/8W 0805; Footprint Url: http://www.yageo.com/exep/pages/download/literatures/PYu-R_Mount_10.pdf; Height: 0.6mm; Ipc Land Pattern Name: RESC200125X50; Lead Free: Yes; Library Name: Altium Content Vault; Octopart: https://octopart.com/rt0805brd075r1l-yageo-30569191; Package: RT0805; RoHS: TRUE; Series: RT; Temperature: -55°C to +155°C; Temperature Range High: +155°C; Temperature Range Low: -55°C
SPI_DISP = CMP-1024-00419-1: 0.025" SQ Post Header, Through-hole, Right Angle, -55 to 125 degC, 2.54 mm Pitch, 9-Pin, Male, RoHS; Manufacturer: Samtec; Pitch: 2.54 mm; Component Kind: Standard; ComponentLink1Description: Manufacturer URL; ComponentLink1URL: http://www.samtec.com; ComponentLink2Description: Datasheet; ComponentLink2URL: http://www.samtec.com/documents/webfiles/pdf/TSW_TH.PDF; ComponentLink3Description: Package Specification; ComponentLink3URL: http://www.samtec.com/documents/webfiles/cpdf/TSW-XXX-XX-XXX-X-XX-XXX-MKT.pdf; DatasheetVersion: F-214; Library Name: Altium Content Vault; Mounting Technology: Through-hole; Orientation: Right Angle; PackageDescription: .025[0.64] SQ. Post Terminal Strip Assembly; PackageReference: TSW-109-08-X-S-RA; PackageVersion: DM, 8/1988; PartNumber: TSW-109-08-G-S-RA; RoHS: True; Temperature Range: -55 to 125 degC
STM = CMP-0237-00215-3: ARM Cortex-M3 32-bit MCU, 64 KB Flash, 20 KB Internal RAM, 37 I/Os, 48-pin LQFP, -40 to 85 degC, Tray; A/D Converter: 2x12-bit (10 Channel); FLASH Size (Prog) (kB): 64; I/Os (High Current): 37; Internal RAM Size (kB): 20; Operating Frequency(F) (Processor speed) (MHz): 72; Supply Voltage(Vcc) min (V): 2; Component Kind: Standard; ComponentLink1Description: Manufacturer URL; ComponentLink1URL: http://www.st.com/web/en/home.html; ComponentLink2Description: Datasheet; ComponentLink2URL: http://www.st.com/st-web-ui/static/active/en/resource/technical/document/datasheet/CD00161566.pdf; ComponentLink3Description: Package Specification; ComponentLink3URL: http://www.st.com/st-web-ui/static/active/en/resource/technical/document/datasheet/DM00058181.pdf; Core: ARM Cortex-M3; DatasheetVersion: Rev. 16; Library Name: Altium Content Vault; Mounting Technology: Surface Mount; PackageDescription: 48-Pin Low Profile Quad Flat Package, 7 x 7 mm Body, 0.5 mm Pitch; PackageReference: LQFP48; PackageVersion: Rev. 6, 06/2013; Packing: Tray; PartNumber: STM32F103C8T6
STM_SWD STM_UART = CMP-02766-000105-1: CONN HEADER VERT 5POS 2.54MM; Gender: Male; Number of Contacts: 5; Component Kind: Standard; Contact Material: Bronze; Contact Plating: Gold; Library Name: Altium Content Vault; Mount: Through Hole; Number of Rows: 1; REACH SVHC: No SVHC; Termination: Through Hole
T = CMP-00278-84331634-1: SS8050DBU Bipolar (BJT) Single Transistor, NPN, 25 V, 100 MHz, 1 W, 1.5 A, 160; Manufacturer Lifecycle Status: ACTIVE; Collector Base Voltage (VCBO): 40V; Collector Emitter Breakdown Voltage: 25V; Collector Emitter Saturation Voltage: 500mV; Current Rating: 1.5A; Emitter Base Voltage (VEBO): 6V; Frequency: 100MHz; hFE Min: 85; Max Junction Temperature (Tj): 150°C; Max Power Dissipation: 1W; Number of Elements: 1; Output Power: 2W; Case/Package: TO-92; Collector Emitter Voltage (VCEO): 25V; Component Kind: Standard; Element Configuration: Single; Gain Bandwidth Product: 100MHz; Height: 4.58mm; Lead Free: Lead Free; Length: 4.58mm; Library Name: Altium Content Vault; Lifecycle Status: Production; Max Collector Current: 1.5A; Max Frequency: 100MHz; Max Operating Temperature: 150°C; Min Operating Temperature: -65°C; Mount: Through Hole; NexarPartId: 84331634; Number of Pins: 3; Packaging: Bulk; Polarity: NPN; Power Dissipation: 1W; Radiation Hardening: No; REACH SVHC: No SVHC; RoHS: Compliant; Schedule B: 8541290080, 8541290080; Transition Frequency: 100MHz; Voltage Rating (DC): 25V; Weight: 179mg; Width: 3.86mm
TEMP_IN = CMP-17439-000014-3: CONN HEADER VERT 3POS 2.5MM; Contact Resistance: 20mR; Current Rating: 3A; Gender: Male; Insulation Resistance: 1GR; Max Voltage Rating (AC): 250V; Number of Contacts: 3; Wire/Cable Gauge: 22AWG; Color: Natural; Component Kind: Standard; Connector Type: Header; Contact Finish - Mating: TIN; Contact Material: Brass; Contact Plating: Tin; Contact Shape: Square; Contact Type: Male Pin; Depth: 5.75mm; Fastening Type: Detent; Flammability Rating: UL94 V-0; Housing Color: White; Housing Material: Polyamide; Insulation Color: Natural; Insulation Material: Nylon; Lead Free: Lead Free; Lead Pitch: 2.5mm; Length: 9.9mm; Library Name: Altium Content Vault; Max Current Rating: 3A; Max Operating Temperature: 85°C; Max Voltage Rating (DC): 250V; Min Operating Temperature: -25°C; Mount: Through Hole; Number of Positions: 3; Number of Rows: 1; Orientation: Straight; Packaging: Bulk; Pitch: 2.5mm; REACH SVHC: No SVHC; Series: XH; Termination: Solder; Voltage Rating: 250V; Voltage Rating (AC): 250V
TTL_ESP = CMP-1024-00364-1: 0.025" SQ Post Header, Through-hole, Vertical, -55 to 105 degC, 2.54 mm Pitch, 5-Pin, Male, RoHS; Manufacturer: Samtec; Pitch: 2.54 mm; Component Kind: Standard; ComponentLink1Description: Manufacturer URL; ComponentLink1URL: http://www.samtec.com; ComponentLink2Description: Datasheet; ComponentLink2URL: http://www.samtec.com/documents/webfiles/pdf/TSW_TH.PDF; ComponentLink3Description: Package Specification; ComponentLink3URL: http://www.samtec.com/documents/webfiles/cpdf/TSW-XXX-XX-XXX-X-XX-XXX-MKT.pdf; DatasheetVersion: F-214; Library Name: Altium Content Vault; Mounting Technology: Through-hole; Orientation: Vertical; PackageDescription: .025[0.64] SQ. Post Terminal Strip Assembly; PackageReference: TSW-105-05-X-S; PackageVersion: DM, 8/1988; PartNumber: TSW-105-05-T-S; RoHS: True; Temperature Range: -55 to 105 degC
X1 = CMP-200220-000019-1: CRYSTAL 32.7680KHZ 12.5PF SMD; ESR (Equivalent Series Resistance): 80kR; Frequency: 32.768kHz; Frequency Tolerance: 20ppm; Case/Package: SMD/SMT; Component Kind: Standard; Height: 0.024inch; Length: 0.081inch; Library Name: Altium Content Vault; Load Capacitance: 12.5pF; Max Operating Temperature: 85°C; Min Operating Temperature: -40°C; Mount: Surface Mount; Packaging: Cut Tape; RoHS Compliant: Yes; Series: NX2012SA; Width: 0.047inch
X2 = CMP-2000-07595-1: Crystal Unit, 8 MHz, 8 pF, -40 to 150 degC, 2-Pin SMD, RoHS, Tape and Reel; Manufacturer: NDK; ESR (Equivalent Series Resistance): 500Ohm; Frequency: 8MHz; Frequency Stability: 150ppm; Frequency Tolerance: 50ppm; Load Capacitance: 8pF; Case/Package: SMD/SMT; Component Kind: Standard; Datasheet URL: http://www.ndk.com/images/products/catalog/c_NX3225GD-STD-CRA-3_e.pdf; Datasheet Version: 11/2016; Height: 0.039inch; Length: 0.126inch; Library Name: Altium Content Vault; Manufacturer URL: http://www.ndk.com/en/; Max Operating Temperature: 150degC; Min Operating Temperature: -40degC; Mount: SurfaceMount; Mounting Technology: SurfaceMount; Number of Pins: 2; Package Description: 2-Pin Surface Mount Device, Body 3.2 x 2.5 mm; Package Quantity: 3000; Package Reference: NX3225GD-8MHZ-STD-CRA-3; Packaging: TapeandReel; RoHSCompliant: True; Width: 0.098inch
